# Altium schematic sheet Sheet2.SchDoc
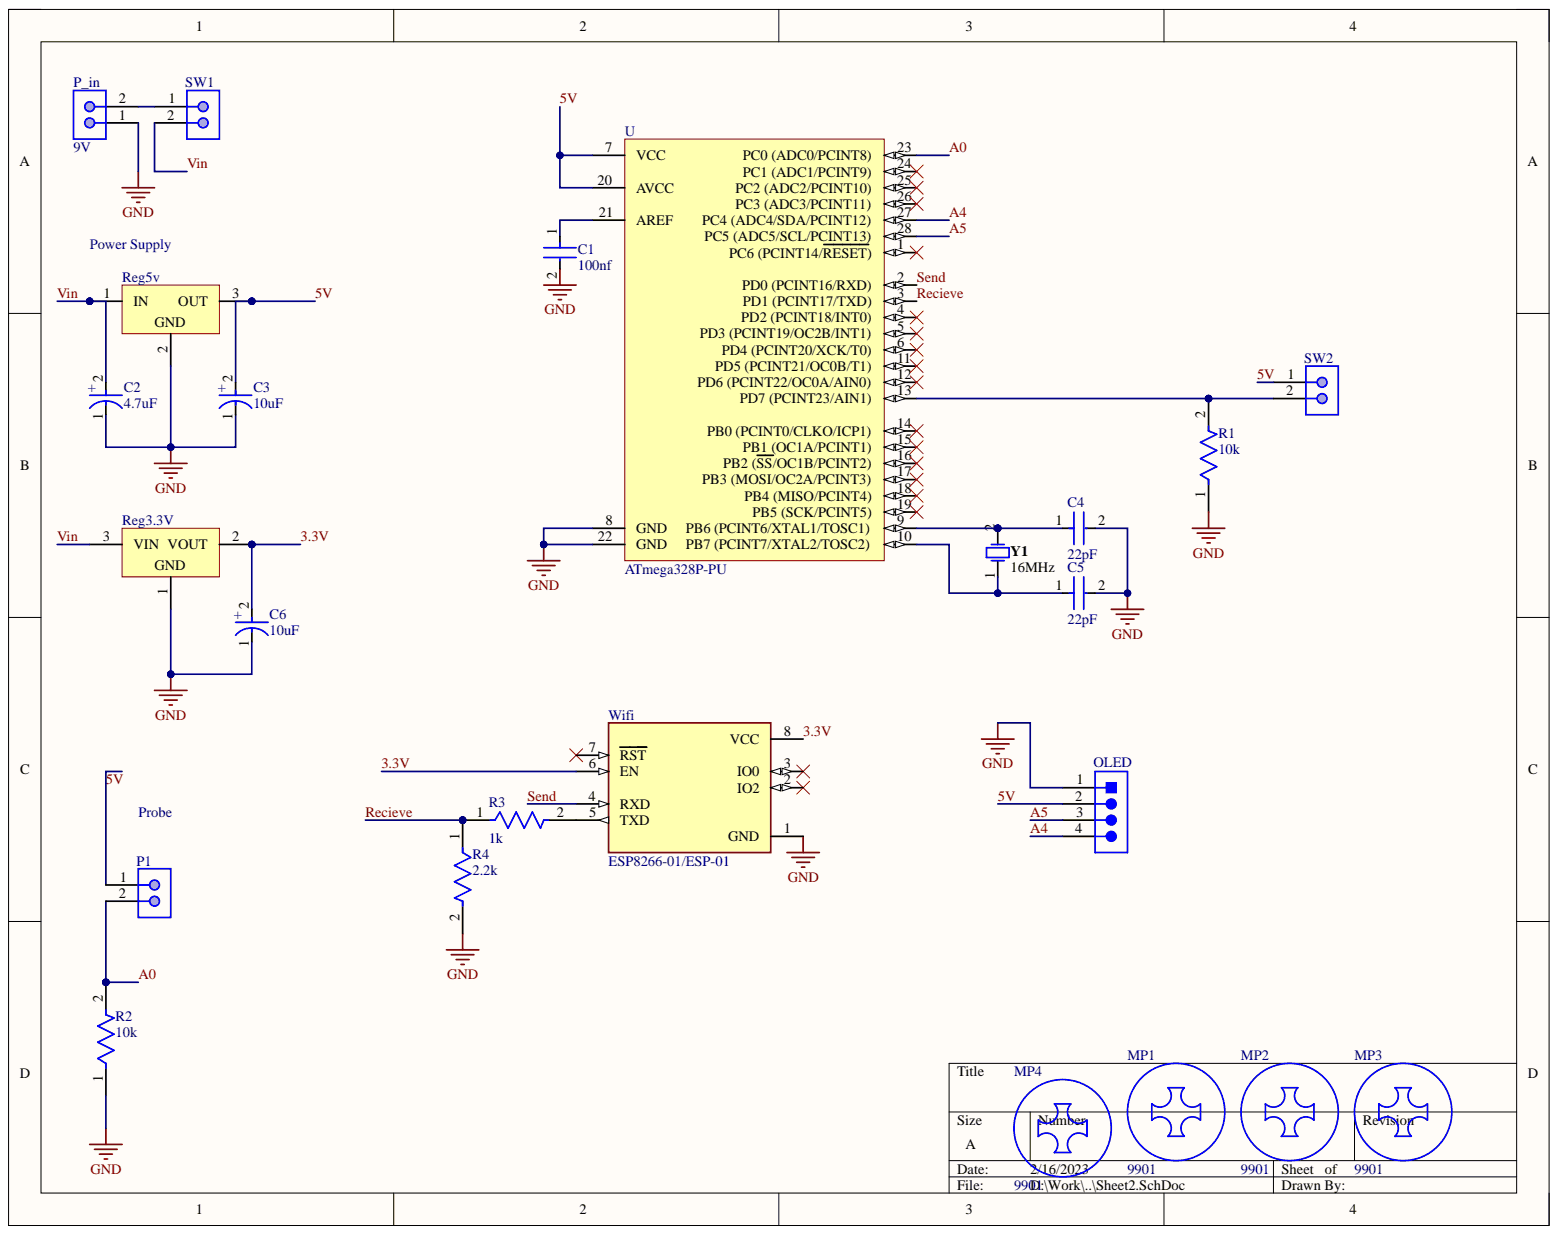
[diagram p0: Sheet2.SchDoc]

## Parts
C1  100nf  [FP-C322C104K5R5TA-MFG]
C2  4.7uF  [FP-RAD-TH-D_6_3_0_5-L_11-MFG]
C3  10uF  [FP-RAD-TH-D_5_0_5-L_11_1-MFG]
C4  22pF  [FP-C322C104K5R5TA-MFG]
C5  22pF  [FP-C322C104K5R5TA-MFG]
C6  10uF  [FP-RAD-TH-D_5_0_5-L_11_1-MFG]
MP1  9901  [FP-9901-MFG]
MP2  9901  [FP-9901-MFG]
MP3  9901  [FP-9901-MFG]
MP4  9901  [FP-9901-MFG]
OLED  6  [TECO-640456-4]  (value not drawn)
P1  5-146280-2  [TECO-5-146280-2_V]  (value not drawn)
P_in  9V  [MOLX-22-27-2021_V]
R1  10k  [FP-CF18-MFG]
R2  10k  [FP-CF18-MFG]
R3  1k  [FP-CF18-MFG]
R4  2.2k  [FP-CF18-MFG]
Reg3.3V  LD1117V33  [TO220]  (value not drawn)
Reg5v  5V  [TO220]
SW1  5-146280-2  [TECO-5-146280-2_V]  (value not drawn)
SW2  5-146280-2  [TECO-5-146280-2_V]  (value not drawn)
U  ATmega328P-PU  [28P3]
Wifi  ESP8266-01/ESP-01  [XCVR_ESP8266-01/ESP-01]
Y1  16MHz  [FOX-FOXSLF160-20]

## Nets
GND: C1.2 C2.1 C3.1 C4.2 C5.2 C6.1 OLED.1 P_in.1 R1.1 R2.1 R4.2 Reg3.3V.1 Reg5v.2 U.8(GND) U.22(GND) Wifi.1(GND)
3.3V: C6.2 Reg3.3V.2 Wifi.6(EN) Wifi.8(VCC)
5V: C3.2 OLED.2 P1.1 Reg5v.3 SW2.1 U.7(VCC) U.20(AVCC)
A0: P1.2 R2.2 U.23(PC0_(ADC0/PCINT8))
A4: OLED.4 U.27
A5: OLED.3 U.28(PC5_(ADC5/SCL/PCINT13))
Recieve: R3.1 R4.1 U.3(PD1_(PCINT17/TXD))
Send: U.2(PD0_(PCINT16/RXD)) Wifi.4(RXD)
Vin: C2.2 Reg3.3V.3 Reg5v.1 SW1.2
NetC1_1: C1.1 U.21(AREF)
NetC4_1: C4.1 U.9 Y1.2
NetC5_1: C5.1 U.10 Y1.1
NetP_in_2: P_in.2 SW1.1
NetR1_2: R1.2 SW2.2 U.13(PD7_(PCINT23/AIN1))
NetR3_2: R3.2 Wifi.5(TXD)

Not connected: U 1(PC6_(PCINT14/RESET)) 4(PD2_(PCINT18/INT0)) 5(PD3_(PCINT19/OC2B/INT1)) 6(PD4_(PCINT20/XCK/T0)) 11(PD5_(PCINT21/OC0B/T1)) 12(PD6_(PCINT22/OC0A/AIN0)) 14(PB0_(PCINT0/CLKO/ICP1)) 15(PB1_(OC1A/PCINT1)) 16(PB2_(SS/OC1B/PCINT2)) 17(PB3_(MOSI/OC2A/PCINT3)) 18(PB4_(MISO/PCINT4)) 19(PB5_(SCK/PCINT5)) 24(PC1_(ADC1/PCINT9)) 25 26(PC3_(ADC3/PCINT11)); Wifi 2(IO2) 3(IO0) 7(RST)

## Library data of the parts
C1 = CMP-03020-034661-1: CAP CER 0.1UF 50V X7R RADIAL; Dielectric Material: Ceramic; Dissipation Factor: 2.5%; Lead Diameter: 0.51mm; Lead Length: 7mm; Lead/Base Style: Formed Leads; Tolerance: 10%; Capacitance: 100nF; Case/Package: Radial; Component Kind: Standard; Construction: RADIAL; Depth: 3.18mm; Dielectric: X7R; Height: 3.18mm; Height - Seated (Max): 0.26inch; Lead Free: Lead Free; Lead Pitch: 5.08mm; Length: 5.08mm; Library Name: Altium Content Vault; Material: Ceramic; Max Operating Temperature: 125°C; Min Operating Temperature: -55°C; Mount: Through Hole; Number of Pins: 2; Package Quantity: 500; Packaging: Bulk; Radiation Hardening: No; REACH SVHC: No SVHC; RoHS Compliant: Yes; Series: Golden Max™; Termination: Radial; Voltage: 50V; Voltage Rating: 50V; Voltage Rating (DC): 50V; Weight: 0.0625lb; Width: 0.125inch
C2 = CMP-05427-000237-1: Aluminum Electrolytic Capacitors (Radial Lead Type) 4.7uF ±20% 160V; Dielectric Material: Aluminium; Dissipation Factor: 0.15%; Lead Diameter: 0.5mm; Lead/Base Style: Straight; Life (Hours): 2000Hour; Ripple Current: 43mA; Capacitance: 4.7uF; Case/Package: Radial; Component Kind: Standard; Construction: RADIAL; Diameter: 6.3mm; Height: 11.2mm; Height - Seated (Max): 0.48inch; Lead Free: Lead Free; Lead Pitch: 2.5mm; Length: 11.2mm; Library Name: Altium Content Vault; Max Operating Temperature: 105°C; Min Operating Temperature: -25°C; Mount: Through Hole; Number of Pins: 2; Package Quantity: 200; Packaging: Bulk; Radiation Hardening: No; REACH SVHC: No SVHC; Ripple Current (AC): 43mA; RoHS Compliant: Yes; Series: NHG; Termination: Radial; Tolerance: 20%; Voltage: 160V; Voltage Rating: 160V; Voltage Rating (DC): 160V; Weight: 0.01lb; Width: 0.25inch
C3 C6 = CMP-05427-000869-1: Aluminum Electrolytic Capacitors (Radial Lead Type) 10uF ±20% 100V; Dielectric Material: Aluminium; Dissipation Factor: 0.1%; Lead Diameter: 0.5mm; Lead/Base Style: Straight; Leakage Current: 3uA; Life (Hours): 2000Hour; Polarity: Polar; Ripple Current: 70mA; Capacitance: 10uF; Case/Package: Radial; Component Kind: Standard; Construction: RADIAL; Contact Plating: Tin; Diameter: 5mm; Height: 11mm; Height - Seated (Max): 0.472inch; Lead Free: Lead Free; Lead Pitch: 2mm; Length: 11mm; Library Name: Altium Content Vault; Max Operating Temperature: 85°C; Min Operating Temperature: -40°C; Mount: Through Hole; Number of Pins: 2; Package Quantity: 200; Packaging: Bulk; Radiation Hardening: No; REACH SVHC: No SVHC; Ripple Current (AC): 70mA; RoHS Compliant: Yes; Series: M; Termination: Radial; Tolerance: 20%; Voltage: 100V; Voltage Rating: 100V; Voltage Rating (DC): 100V; Width: 5mm
C4 C5 = CMP-03020-034661-1: CAP CER 0.1UF 50V X7R RADIAL; Capacitance: 100nF; Dielectric Material: Ceramic; Dissipation Factor: 2.5%; Lead Diameter: 0.51mm; Lead Length: 7mm; Lead/Base Style: Formed Leads; Tolerance: 10%; Case/Package: Radial; Component Kind: Standard; Construction: RADIAL; Depth: 3.18mm; Dielectric: X7R; Height: 3.18mm; Height - Seated (Max): 0.26inch; Lead Free: Lead Free; Lead Pitch: 5.08mm; Length: 5.08mm; Library Name: Altium Content Vault; Material: Ceramic; Max Operating Temperature: 125°C; Min Operating Temperature: -55°C; Mount: Through Hole; Number of Pins: 2; Package Quantity: 500; Packaging: Bulk; Radiation Hardening: No; REACH SVHC: No SVHC; RoHS Compliant: Yes; Series: Golden Max™; Termination: Radial; Voltage: 50V; Voltage Rating: 50V; Voltage Rating (DC): 50V; Weight: 0.0625lb; Width: 0.125inch
MP1-MP4 = CMP-19664-000002-1: MACHINE SCREW PAN PHILLIPS 4-40; Head Height: 0.065inch; Outside Diameter: 0.212inch; Thread Size: #4-40; Component Kind: Standard; Lead Free: Lead Free; Length: 0.44inch; Library Name: Altium Content Vault; Material: Stainless Steel; Mount: Screw; Package Quantity: 100; Series: -
OLED = CMP-2000-05419-1: Male Header, Pitch 2.54 mm, 1 x 4 Position, Height 10.03 mm, Tail Length 3.56 mm, RoHS, Bulk; Manufacturer: TE Connectivity; Agency Approvals: CSA,UL; Angle: Straight; Brand/Series: MTA-100Series; Color, Housing: White; Connector Type: MTA-100; Contact Centerline Spacing: 0.100    in.; Contact Termination Area Plating: Tin; Current, Rating: 5    A; Material, Contact: CopperAlloy; Material, Housing: Polyester; Mount Angle: Vertical; Mounting Hole Size: 0.04    "; Number of Contacts: 4; Pin Spacing: 0.1    "; Primary Type: InterconnectSystem; Special Features: Pinstrip; Standards: RoHSCompliant,ELVCompliant,UL94V-0; Temperature, Operating: -55to+105    degC; Voltage, Rating: 250    V; Color: White; Component Kind: Standard; Contact Mating Area Plating: Tin; Contact Plating: Tin; Contact Shape: Square; Datasheet URL: https://datasheet.ciiva.com/16286/eng-ds-82056-mta-0608-16286228.pdf; Datasheet Version: Dec-14; Flammability Rating: UL94V-0; Length, Overall: 0.4    "; Length, Pin: 0.14    "; Length, Terminal Post: 0.14    in.; Library Name: Altium Content Vault; Manufacturer URL: http://www.te.com/; Mounting Type: PCMount; Number of Positions: 4; Number of Rows: 1; Size, Post: 0.025    in.; Termination: Solder; Type: PCBPlug
P1 SW1 SW2 = CMP-2000-07492-1: Male Header, Pitch 2.54 mm, 1 x 2 Position, Height 8.21 mm, Tail Length 3.05 mm, -65 to 105 degC, RoHS, Bulk; Manufacturer: TE Connectivity; Approvals: CSA; Board Standoff: No(Without); Case\/Package: 100; Current Rating: 3A; High Speed Serial Data Connector: No; High Temperature Housing: Yes; Mating Post Length: 0.23inch; Max Current Rating: 5A; Number of Contacts: 2; PCB Mount Retention: Without; PCB Mounting Orientation: Vertical; PCB Thickness: 0.063inch; Pitch: 2.54mm; Colour: Black; Component Kind: Standard; Connector Type: Header; Contact Gender: Male; Contact Material: CopperAlloy; Contact Mating Length: 0.23inch; Contact Plating: Matte; Contact Protection: No(Without); Contact Shape: Square; Datasheet URL: http://www.te.com/commerce/DocumentDelivery/DDEController?Action=showdoc&DocId=Customer+Drawing%7F146280%7FH1%7Fpdf%7FEnglish%7FENG_CD_146280_H1.pdf%7F5-146280-2; Datasheet Version: Rev. H1, 08/2016; Depth: 2.34mm; Flammability Rating: UL94V-0; Gasket: No(Without); Housing Colour: Black; Housing Material: Polymer; Keyed: No; Length: 4.67mm; Library Name: Altium Content Vault; Manufacturer URL: http://www.te.com/; Mating Alignment: No(Without); Mating Connector Lock: No(Without); Max Operating Temperature: 105degC; Min Operating Temperature: -65degC; Mount: ThroughHole; Mounting Technology: ThroughHole; Number of Positions: 2; Number of Rows: 1; Orientation: Straight; Package Description: Male Header, Pitch 2.54 mm, 1 x 2 Position, Height 8.21 mm, Tail Length 3.05 mm; Package Quantity: 500; Package Reference: 5-146280-2; Packaging: Carton; Panel Mount Retention: No(Without); Radiation Hardening: No; RoHSCompliant: true; Row Spacing: 2.54mm; Selectively Loaded: No(Without); Stabilizers: No(Without); Termination: ThroughHole; Termination Post Length: 0.12inch
P_in = CMP-2000-05537-1: Male Header, Pitch 2.54 mm, 1 x 2 Position, Height 11.7 mm, Tail Length 3.56 mm, 0 to 75 degC, RoHS, Bulk; Manufacturer: Molex; PartNumber: 0022272021; Current - Max: 4A; No. of Positions: 2; No. of Rows: 1; Package Version: Rev. BC, 02/2012; Pitch: 2.54mm; Voltage - Max: 250V; Component Kind: Standard; Contact material: Tinplatedbrass; Current rating: 4A; Datasheet URL: http://www.molex.com/pdm_docs/sd/022272021_sd.pdf; Datasheet Version: Rev. F, 06/2009; Housing material: Nylon(UL94V-0); Library Name: Altium Content Vault; Manufacturer URL: http://www.molex.com/; Mounting Technology: ThroughHole; Operating temperature: 0°Cto+75°C; Orientation: Vertical; Package Description: 2-Leads Header, Body 5.08 x 5.8 mm, Pitch 2.54 mm; Package Reference: 22-27-2021; Voltage rating: 250V
R1-R4 = CMP-26528-000002-1: RES 10K OHM 1/8W 5% CF AXIAL; Manufacturer: Stackpole Electronics; Manufacturer Part Number: CF18JT10K0; Power Rating: 0.125W; Resistance: 10kΩ; Temperature Coefficient: 0-500ppm/°C; Value: 10kΩ; Voltage Rating: 250V; Automotive: No; Category: Res; Component Kind: Standard; ComponentLink2Description: Datasheet; ComponentLink2URL: http://www.seielect.com/Catalog/SEI-CF_CFM.pdf; Composition: Carbon Film; Device Class L1: Passive Components; Device Class L2: Resistors; Device Class L3: Through-Hole Resistors; Digikey Description: RES 10K OHM 1/8W 5% CF AXIAL; Height: 2mm; Ipc Land Pattern Name: RESAD656W65L360D200; Lead Free: Yes; Library Name: Altium Content Vault; Octopart: https://octopart.com/cf18jt10k0-stackpole+electronics-19205642; Package: CF18; RoHS: TRUE; Series: CF; Temperature: -55°C to +155°C; Temperature Range High: +155°C; Temperature Range Low: -55°C; Tolerance: 5%
Reg3.3V = CMP-0244-00470-1: Fixed Low Drop Positive Voltage Regulator, 3.3V, 3-Pin TO-220; Component Kind: Standard; ComponentLink1Description: Manufacturer URL; ComponentLink1URL: http://www.st.com; ComponentLink2Description: Datasheet; ComponentLink2URL: http://www.st.com/internet/com/TECHNICAL_RESOURCES/TECHNICAL_LITERATURE/DATASHEET/CD00000544.pdf; ComponentLink3Description: Package Specification; ComponentLink3URL: http://www.st.com/internet/com/TECHNICAL_RESOURCES/TECHNICAL_LITERATURE/PACKAGE_INFORMATION/CD00004649.pdf; DatasheetVersion: 1/11/2011; Library Name: Altium Content Vault; PackageDescription: TO, Thru-Hole, Vertical, Heatsink Mounted; 3 In-Line Leads; Pitch 2.54 mm; PackageReference: TO220; PackageVersion: ver3.0
Reg5v = CMP-0244-00495-1: Positive Voltage Regulator, 6V, 3-Pin TO-220; Component Kind: Standard; ComponentLink1Description: Manufacturer URL; ComponentLink1URL: http://www.st.com; ComponentLink2Description: Datasheet; ComponentLink2URL: http://www.st.com/internet/com/TECHNICAL_RESOURCES/TECHNICAL_LITERATURE/DATASHEET/CD00000444.pdf; ComponentLink3Description: Package Specification; ComponentLink3URL: http://www.st.com/internet/com/TECHNICAL_RESOURCES/TECHNICAL_LITERATURE/PACKAGE_INFORMATION/CD00004649.pdf; DatasheetVersion: 16/11/2011; Library Name: Altium Content Vault; PackageDescription: TO, Thru-Hole, Vertical, Heatsink Mounted; 3 In-Line Leads; Pitch 2.54 mm; PackageReference: TO220; PackageVersion: ver3.0
U = CMP-0095-00013-2: 8-bit AVR Microcontroller, 32KB Flash, 1KB EEPROM, 2KB SRAM, 28-pin PDIP, Industrial Grade (-40°C to 85°C); Component Kind: Standard; ComponentLink1Description: Manufacturer URL; ComponentLink1URL: http://www.atmel.com/; ComponentLink2Description: ATmega48A/48PA/88A/88PA/168A/168PA/328/328P Datasheet; ComponentLink2URL: http://www.atmel.com/dyn/resources/prod_documents/8271.pdf; ComponentLink3Description: ATmega48A/48PA/88A/88PA/168A/168PA/328/328P Summary; ComponentLink3URL: http://www.atmel.com/dyn/resources/prod_documents/8271S.pdf; DatasheetVersion: revD, May-2011; Library Name: Altium Content Vault; PackageDescription: 28-lead (0.300"/7.62mm Wide) Plastic Dual Inline Package (PDIP); PackageReference: 28P3; PackageVersion: revB, Sep-2001; RevisionNote: DatasheetVersion updated. URLs added.
Wifi = ESP8266-01/ESP-01: MakerFocus 4pcs ESP8266 ESP-01 Serial Wireless WiFi Transceiver Receiver Module 1MB SPI Flash DC3.0-3.6V Internet of Things WiFi Module Board Compatible with Ar duino; Availability: Unavailable; Component Kind: Standard; Library Name: ESP8266-01_ESP-01.IntLib; MP: ESP8266-01/ESP-01; Package: None; Price: None
Y1 = CMP-1749-00003-1: Resistance Weld Thru-Hole Crystal, 16 MHz, -20 to 70 degC, 2-Pin THD, RoHS, Bulk; Case\Package: Axial; Power Rating: 250 mW; Resistance: 221 kohm; Tolerance: 1%; Voltage Rating: 250 V; Component Kind: Standard; ComponentLink1Description: Manufacturer URL; ComponentLink1URL: http://www.foxonline.com/; ComponentLink2Description: Datasheet; ComponentLink2URL: http://www.foxonline.com/pdfs/hc49slf.pdf; DatasheetVersion: Mar-15; Length: 0.248 inch; Library Name: Altium Content Vault; Max Operating Temperature: 155 degC; Min Operating Temperature: -55 degC; Mount: Through Hole; Package Quantity: 200; PackageDescription: 2-Pin Through Hole Device, Body 11.35 x 4.65 mm; PackageReference: FOXSLF160-20; Packaging: Bulk; RoHSCompliant: true
